# Altium schematic sheet Sensor-Sheet2.SchDoc(Sensor-Sheet2)
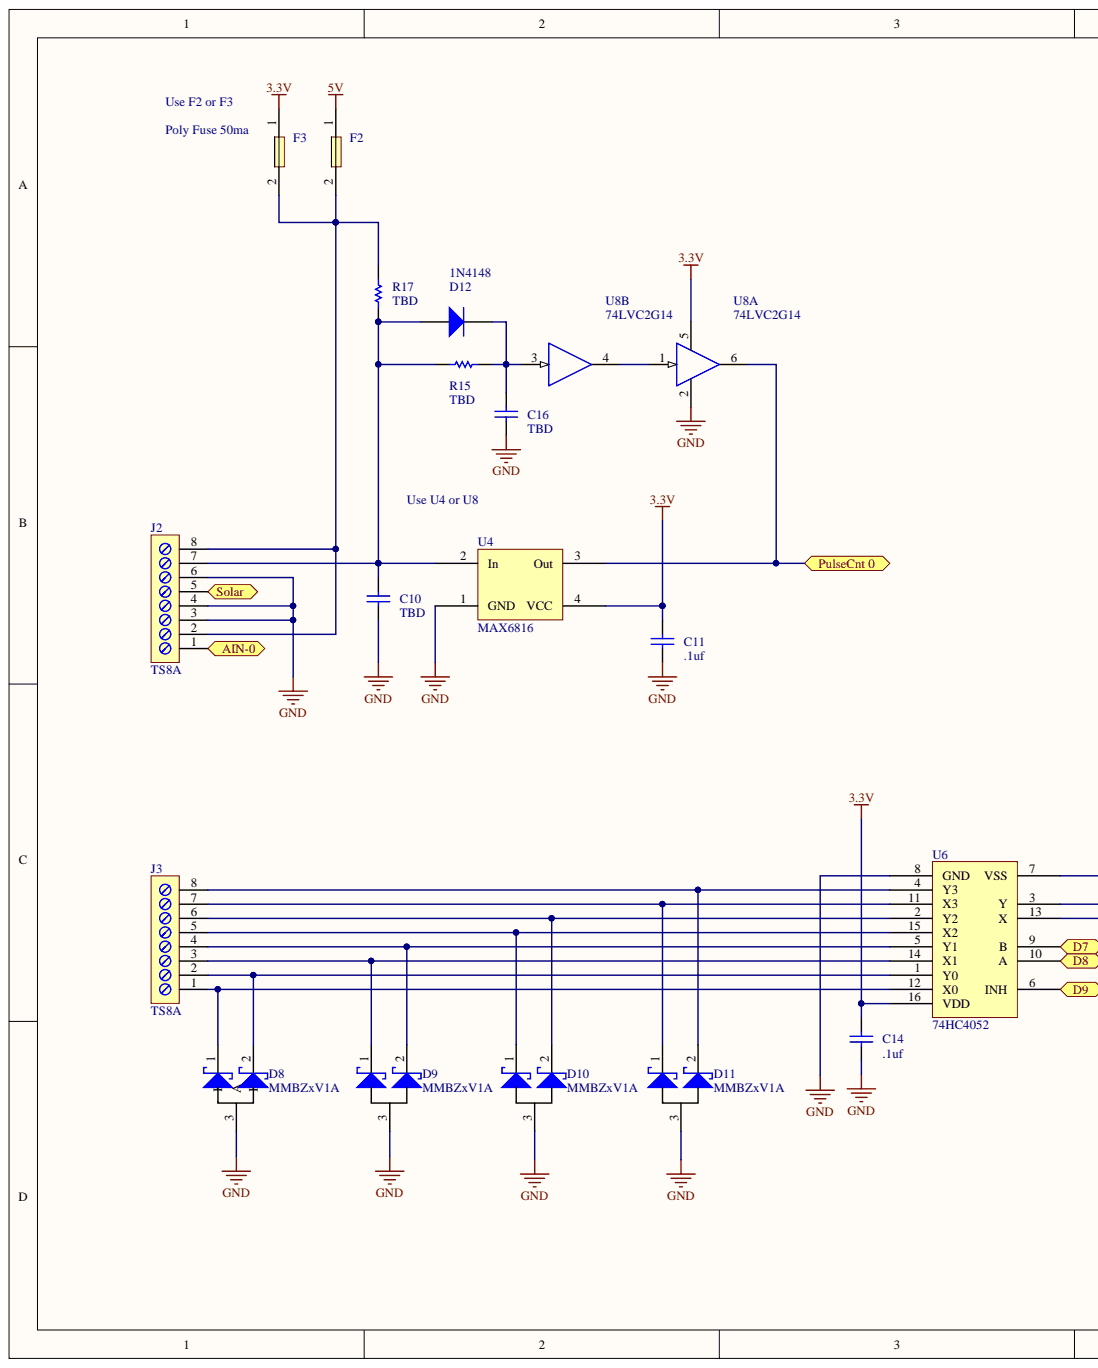
[diagram p0: Sensor-Sheet2.SchDoc, left]
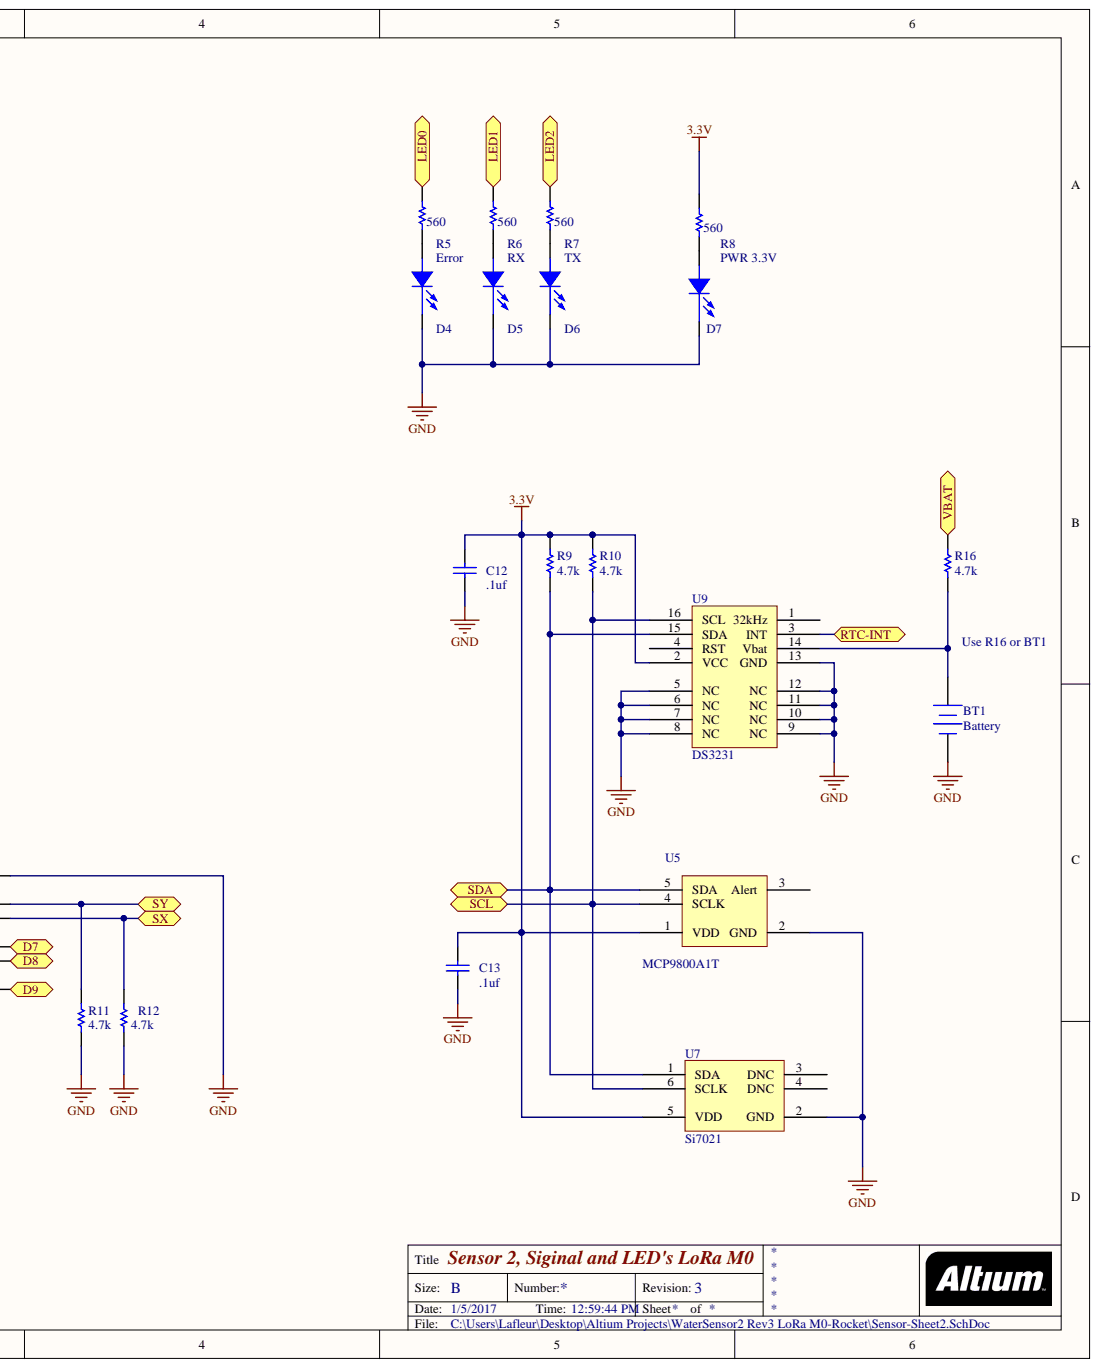
[diagram p1: Sensor-Sheet2.SchDoc, right]

## Parts
BT1  Battery  [BR1632/V1AN]
C10  TBD  [0805]
C11  .1uf  [0805]
C12  .1uf  [0805]
C13  .1uf  [0805]
C14  .1uf  [0805]
C16  TBD  [0805]
D4  Error  [LED 0805]
D5  RX  [LED 0805]
D6  TX  [LED 0805]
D7  PWR 3.3V  [LED 0805]
D8  MMBZxV1A  [SOT23]
D9  MMBZxV1A  [SOT23]
D10  MMBZxV1A  [SOT23]
D11  MMBZxV1A  [SOT23]
D12  1N4148  [SOD-323]
F2  Poly Fuse 50ma  [C1210]
F3  Poly Fuse 50ma  [C1210]
J2  TS8A  [TS3.81X8]
J3  TS8A  [TS3.81X8]
R5  560  [6-0805]
R6  560  [6-0805]
R7  560  [6-0805]
R8  560  [6-0805]
R9  4.7k  [6-0805]
R10  4.7k  [6-0805]
R11  4.7k  [6-0805]
R12  4.7k  [6-0805]
R15  TBD  [6-0805]
R16  4.7k  [6-0805]
R17  TBD  [6-0805]
U4  MAX6816  [SOT143-4]
U5  MCP9800A1T  [SOT-23-OT5_L]
U6  74HC4052  [SOP127P1030X265-16L]
U7  Si7021  [QFN3x3-6pin]
U8  74LVC2G14  [sot23-6]  (parts A,B)
U9  DS3231  [SOP127P1030X265-16L]

## Ports
AIN-0, D7, D8, D9, LED0, LED1, LED2, PULSECNT 0, RTC-INT, SCL, SDA, SOLAR, SX, SY, VBAT

## Nets
3.3V: C11.1 C12.1 C13.1 C14.1 F3.1 R8.2 R9.2 R10.2 U4.4(VCC) U5.1(VDD) U6.16(VDD) U7.5(VDD) U8.5 U9.2(VCC)
5V: F2.1
GND: BT1.2 C10.2 C11.2 C12.2 C13.2 C14.2 C16.2 D4.2 D5.2 D6.2 D7.2 D8.3 D9.3 D10.3 D11.3 J2.3 J2.4 J2.6 R11.1 R12.1 U4.1(GND) U5.2(GND) U6.7(VSS) U6.8(GND) U7.2(GND) U8.2 U9.5(NC) U9.6(NC) U9.7(NC) U9.8(NC) U9.9(NC) U9.10(NC) U9.11(NC) U9.12(NC) U9.13(GND)
AIN-0: J2.1 ; port AIN-0
D7: U6.9(B) ; port D7
D8: U6.10(A) ; port D8
D9: U6.6(INH) ; port D9
LED0: R5.2 ; port LED0
LED1: R6.2 ; port LED1
LED2: R7.2 ; port LED2
RTC-INT: U9.3(INT) ; port RTC-INT
SCL: R10.1 U5.4(SCLK) U7.6(SCLK) U9.16(SCL) ; port SCL
SDA: R9.1 U5.5(SDA) U7.1(SDA) U9.15(SDA) ; port SDA
SX: R12.2 U6.13(X) ; port SX
SY: R11.2 U6.3(Y) ; port SY
VBAT: R16.2 ; port VBAT
NetBT1_1: BT1.1 R16.1 U9.14(Vbat)
NetC10_1: C10.1 D12.1 J2.7 R15.2 R17.2 U4.2(In)
NetC16_1: C16.1 D12.2 R15.1 U8.3
NetD4_1: D4.1 R5.1
NetD5_1: D5.1 R6.1
NetD6_1: D6.1 R7.1
NetD7_1: D7.1 R8.1
NetD8_1: D8.1 J3.1 U6.12(X0)
NetD8_2: D8.2 J3.2 U6.1(Y0)
NetD9_1: D9.1 J3.3 U6.14(X1)
NetD9_2: D9.2 J3.4 U6.5(Y1)
NetD10_1: D10.1 J3.5 U6.15(X2)
NetD10_2: D10.2 J3.6 U6.2(Y2)
NetD11_1: D11.1 J3.7 U6.11(X3)
NetD11_2: D11.2 J3.8 U6.4(Y3)
NetF2_2: F2.2 F3.2 J2.2 J2.8 R17.1
NetJ2_5: J2.5 ; port SOLAR
NetU4_3: U4.3(Out) U8.6 ; port PULSECNT 0
NetU5_3: U5.3(Alert)
NetU7_3: U7.3(DNC)
NetU7_4: U7.4(DNC)
NetU8_1: U8.1 U8.4
NetU9_1: U9.1(32kHz)
NetU9_4: U9.4(RST)

## Library data of the parts
BT1 = Battery: Multicell Battery; Component Kind: Standard; LatestRevisionDate: 17-Jul-2002; LatestRevisionNote: Re-released for DXP Platform.; Library Name: Miscellaneous Devices.IntLib; PackageDescription: Battery; 2 Leads; PackageReference: BAT-2; Published: 24-Mar-1999; Publisher: Altium Limited
C10-C14 C16 = CAP_1: Capacitor; Fitted: True; Component Kind: Standard; Library Name: N2K Project.SCHLIB
D4-D7 = LTST150: Part Description: SMT LED Green; Vendor Part Number: LTST-C150GKRT; DEVICE: C150; Flex_PN: D20000; Vendor: LITEON; Component Kind: Standard; Library Name: Winmor-Demo-R1.IntLib
D8-D11 = MMBZ9V1A: Zener Transient Voltage Suppressors; Component Kind: Standard; Library Name: WaterSensor_5.SCHLIB
D12 = Diode 1N4148: High Conductance Fast Diode; Code_JEDEC: DO-204-AH; Component Kind: Standard; ComponentLink1Description: Manufacturer Link; ComponentLink1URL: http://www.fairchildsemi.com/; ComponentLink2Description: Datasheet; ComponentLink2URL: http://www.fairchildsemi.com/ds/1N%2F1N4148.pdf; DatasheetVersion: 1997; LatestRevisionDate: 17-Jul-2002; LatestRevisionNote: Re-released for DXP Platform.; Library Name: Miscellaneous Devices.IntLib; PackageDescription: Diode, Axial; Body 3.8 x 1.9 mm (LxDia. max), Lead Dia. 0.53 mm (max); PackageReference: DO-35; Published: 8-Jun-2000; Signal Integrity: 1N4148; Simulation: 1N4148; Publisher: Altium Limited
F2 F3 = Poly Fuse: Component Kind: Standard; Description: Poly Fuse; Library Name: N2K Project.SCHLIB
J2 J3 = TS8A: Screw Terminal 3.81mm (.15in) 8X; Component Kind: Standard; Library Name: Winmor-Demo-R1.IntLib
R5-R12 R15-R17 = ERJ8EN: Precision Thick Film Chip Resistor, 10 Ohm to 2.2M Ohm Range, 1% Tolerance, 1206 Size, 0.125 W; Code_IPC: 3216 [1206]; Component Kind: Standard; ComponentLink1Description: Manufacturer Link; ComponentLink1URL: http://www.panasonic.com/industrial/components/resistive_products.htm; ComponentLink2Description: Datasheet; ComponentLink2URL: http://www.panasonic.com/industrial/components/pdf/AOA0000CE2.pdf; LatestRevisionDate: 19-Mar-2004; LatestRevisionNote: Silkscreen size reduced.; PackageDocument: Mar-2003; PackageReference: 8-1206; Published: 17-Dec-2003; Publisher: Altium Limited
  R9-R12 R16: Library Name: N2K Project.SCHLIB; Signal Integrity: Res; Simulation: RESISTOR
  R5-R8 R15 R17: Library Name: Winmor-Demo-R1.IntLib
U4 = MAX6816: Max6816 Switch Debounce, CMOS; Component Kind: Standard; Library Name: WaterSensor_4.SCHLIB
U5 = MCP9800A1T: Temperature Sensor, I2C; Component Kind: Standard; Library Name: WaterSensor_3.SCHLIB
U6 = 74HC4052: Dual 4-channel aAalog Mux; Component Kind: Standard; Library Name: WaterSensor_5.SCHLIB
U7 = Si7021: Humidity & Temperature Sensor, I2C,  3x3mm; Component Kind: Standard; Library Name: WaterSensor_3.SCHLIB
U8 = 74LVC2G07: Dual OC Driver; Code_JEDEC: MO-150-AB; Component Kind: Standard; ComponentLink1Description: Manufacturer Link; ComponentLink1URL: http://www.ti.com/; ComponentLink2Description: Datasheet; ComponentLink2URL: http://www-s.ti.com/sc/ds/sn74ahc14.pdf; ComponentLink3Description: Packaging Specification; ComponentLink3URL: http://focus.ti.com/lit/ml/msso002e/msso002e.pdf; DatasheetVersion: Jul-1998; LatestRevisionDate: 04-Mar-2005; LatestRevisionNote: Stylized 3D Model Added.; PackageDescription: SSOP, Plastic; 14 Leads; Body Width 5.3 mm; Pitch 0.65 mm; PackageReference: DB014; PackageVersion: May-1999; Published: 8-Jun-2000; Library Name: ARDOP-Modem Rev1_5.SCHLIB; Publisher: Altium Limited
U9 = DS3231: Maxim DS3231 I2C Clock; Component Kind: Standard; Library Name: WaterSensor_5.SCHLIB
